# Altium schematic sheet Sheet1.SchDoc(Sheet1)
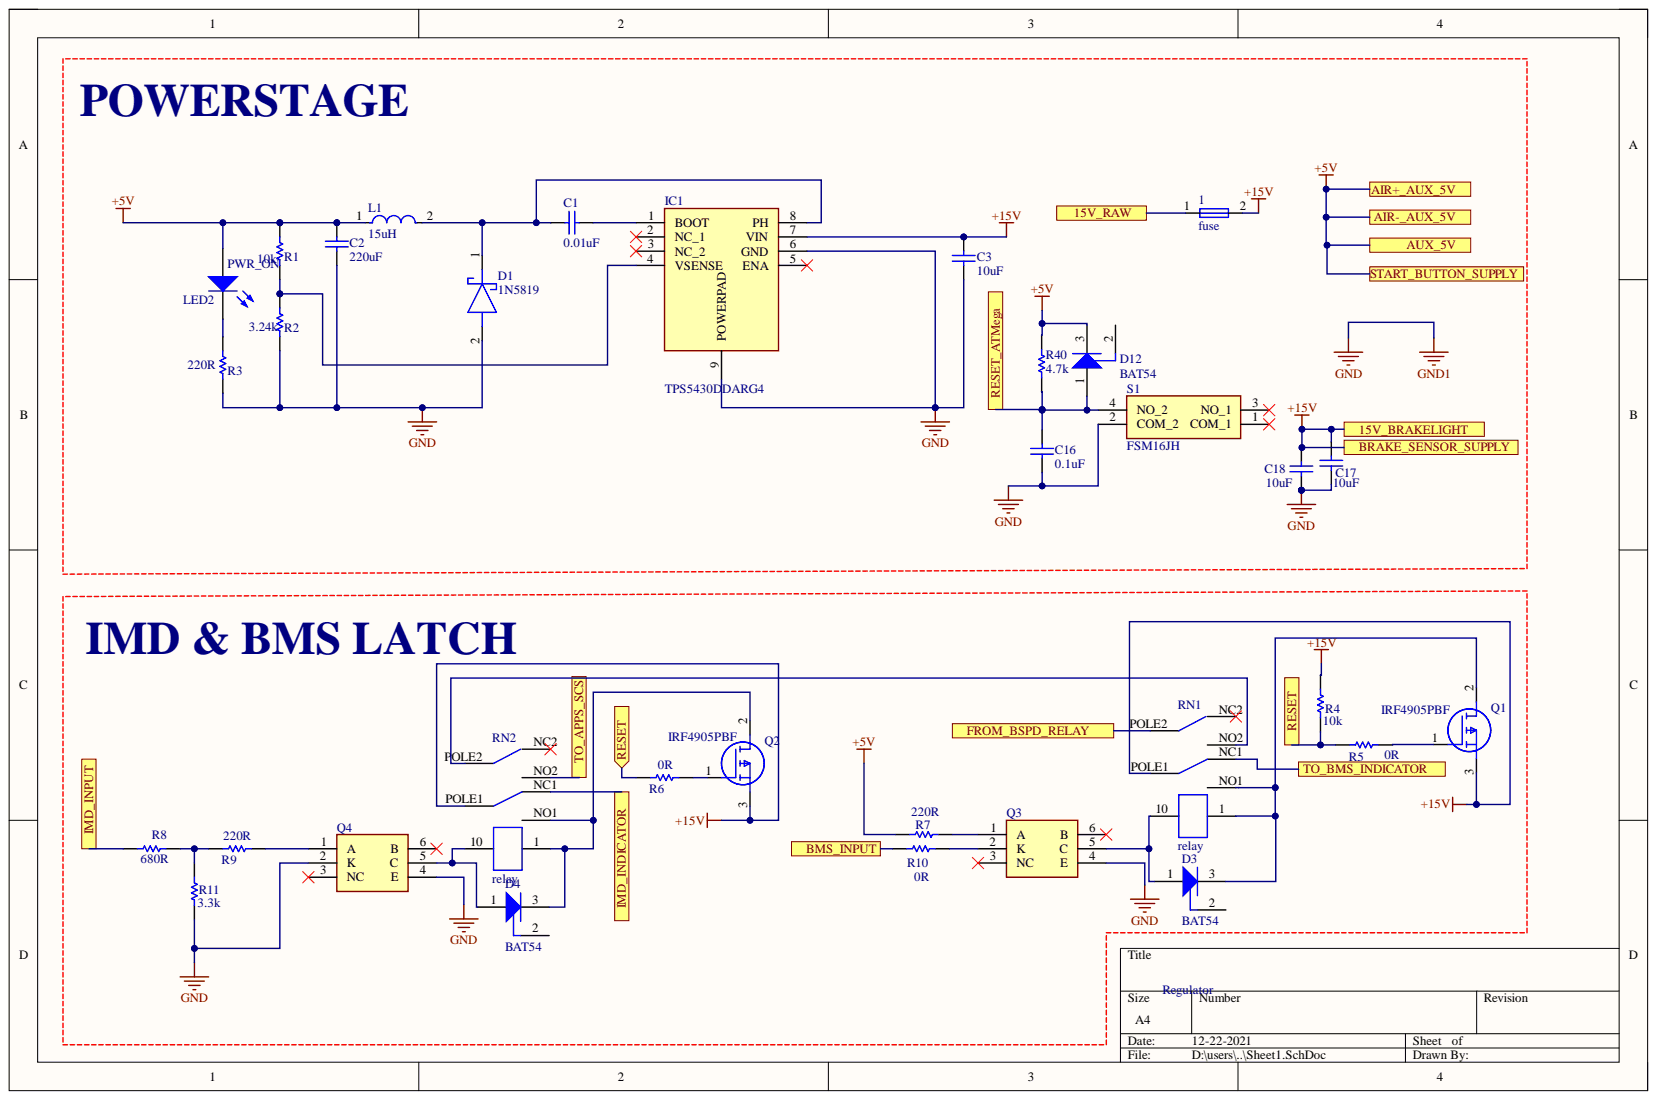
[diagram p0: Sheet1.SchDoc]

## Parts
1  fuse  [fuse]
C1  0.01uF  [KEMT-1206-2_N]
C2  220uF  [KEMT-1206-2_N]
C3  10uF  [KEMT-1206-2_N]
C16  0.1uF  [KEMT-1206-2_N]
C17  10uF  [KEMT-1206-2_N]
C18  10uF  [KEMT-1206-2_N]
D1  1N5819  [DIOAD1796W86L628D292]
D3  BAT54  [INF-SOT23_N]
D4  BAT54  [INF-SOT23_N]
D12  BAT54  [INF-SOT23_N]
IC1  TPS5430DDARG4  [SOIC127P600X175-8N]
L1  15uH  [FP-IR02-MFG]
PWR_ON  LED2  [3.2X1.6X1.1]
Q1  IRF4905PBF  [TO254P469X1042X1967-3P]
Q2  IRF4905PBF  [TO254P469X1042X1967-3P]
Q3  MCT2ESM  [SOT254P916X480-6N]  (value not drawn)
Q4  MCT2ESM  [SOT254P916X480-6N]  (value not drawn)
R1  10k  [RESC3216X60X45NL10T20 - duplicate]
R2  3.24k  [RESC3216X60X45NL10T20 - duplicate]
R3  220R  [RESC3216X60X45NL10T20 - duplicate]
R4  10k  [RESC3216X60X45NL10T20 - duplicate]
R5  0R  [RESC3216X60X45NL10T20 - duplicate]
R6  0R  [RESC3216X60X45NL10T20 - duplicate]
R7  220R  [RESC3216X60X45NL10T20 - duplicate]
R8  680R  [RESC3216X60X45NL10T20 - duplicate]
R9  220R  [RESC3216X60X45NL10T20 - duplicate]
R10  0R  [RESC3216X60X45NL10T20 - duplicate]
R11  3.3k  [RESC3216X60X45NL10T20 - duplicate]
R40  4.7k  [R1206]
RN1  relay  [relaytq]
RN2  relay  [relaytq]
S1  FSM16JH  [FSM16JH]

## Ports
15V_BRAKELIGHT, 15V_RAW, AIR+_AUX_5V, AIR-_AUX_5V, AUX_5V, BMS_INPUT, BRAKE_SENSOR_SUPPLY, FROM_BSPD_RELAY, IMD_INDICATOR, IMD_INPUT, RESET, RESET_ATMega, START_BUTTON_SUPPLY, TO_APPS_SCS, TO_BMS_INDICATOR

## Nets
GND: C2.2 C3.2 C16.1 C17.2 C18.2 D1.2 IC1.6(GND) IC1.9(POWERPAD) Q3.4(E) Q4.2(K) Q4.4(E) R2.1 R3.1 R11.1 S1.2(COM_2)
15V_RAW: 1.1 ; port 15V_RAW
+5V: C2.1 D12.3 L1.1 PWR_ON.1 R1.2 R7.2 R40.1 ; port AUX_5V, port START_BUTTON_SUPPLY
+15V: 1.2 C3.1 C17.1 C18.1 IC1.7(VIN) Q1.3 Q2.3 R4.2 RN2.POLE1 ; port 15V_BRAKELIGHT, port BRAKE_SENSOR_SUPPLY
BMS_INPUT: R10.2 ; port BMS_INPUT
FROM_BSPD_RELAY: RN1.POLE2 ; port FROM_BSPD_RELAY
IMD_INDICATOR: RN2.NC1 ; port IMD_INDICATOR
IMD_INPUT: R8.2 ; port IMD_INPUT
RESET: R4.1 R5.2 R6.2 ; port RESET
RESET_ATMega: C16.2 D12.1 R40.2 S1.4(NO_2) ; port RESET_ATMega
TO_APPS_SCS: RN2.NO2 ; port TO_APPS_SCS
TO_BMS_INDICATOR: RN1.NC1 ; port TO_BMS_INDICATOR
NetC1_1: C1.1 D1.1 IC1.8(PH) L1.2
NetC1_2: C1.2 IC1.1(BOOT)
NetD3_1: D3.1 Q3.5(C) RN1.10
NetD3_3: D3.3 Q1.2 RN1.1 RN1.NO1
NetD4_1: D4.1 Q4.5(C) RN2.10
NetD4_3: D4.3 Q2.2 RN2.1 RN2.NO1
NetIC1_4: IC1.4(VSENSE) R1.1 R2.2
NetPWR_ON_2: PWR_ON.2 R3.2
NetQ1_1: Q1.1 R5.1
NetQ2_1: Q2.1 R6.1
NetQ3_1: Q3.1(A) R7.1
NetQ3_2: Q3.2(K) R10.1
NetQ4_1: Q4.1(A) R9.1
NetR8_1: R8.1 R9.2 R11.2
NetRN1_NO2: RN1.NO2 RN2.POLE2

Not connected: D3 2; D4 2; D12 2; IC1 2(NC_1) 3(NC_2) 5(ENA); Q3 3(NC) 6(B); Q4 3(NC) 6(B); RN1 NC2 POLE1; RN2 NC2; S1 1(COM_1) 3(NO_1)

## Library data of the parts
C1-C3 C16-C18 = CMP-1037-04202-2: CAP, Ceramic, 0.1 uF, +/- 10%, 50 V, -55 to 125 degC, 1206 (3216 Metric), RoHS, Tape and Reel; Manufacturer: Kemet; Capacitance: 0.1µF; Capacitor Case Style: 1206[3216Metric]; Dielectric Characteristic: X7R; Product Range: KEMET-CSeries; SVHC: ToBeAdvised; Voltage Rating: 50V; Capacitance Tolerance: ±10%; Component Kind: Standard; Datasheet URL: https://datasheet.ciiva.com/6125/1725438-6125494.pdf; Datasheet Version: Jul-13; Manufacturer URL: http://www.kemet.com/; Operating Temperature Max: 125degC; Operating Temperature Min: -55degC; Package Description: 2-Leads SMD, Body 3.2 x 1.6 mm; Package Reference: 1206-2; Packaging: Tape&Reel
  C1-C3 C17 C18: Library Name: Schlib1.SchLib
  C16: Library Name: Schlib1_TSAL_LV_3.1.SchLib
D1 = 1N5819: Schottky Diode; Manufacturer_Name: NEUTRAL; Component Kind: Standard; Datasheet Link: http://uk.rs-online.com/web/p/products/1124416; Library Name: SamacSys.SchLib; Manufacturer_Part_Number: 1N5819
D3 D4 D12 = CMP-1182-00010-1: Silicon Schottky Diode, SOT23, Reel, Green; Manufacturer: Infineon; Configuration: Single; CT (max): 10Â pF; Green: TRUE; IF (max): 200Â mA; VF (max): 800Â mV; VR (max): 30Â V; Component Kind: Standard; ComponentLink1Description: Manufacturer URL; ComponentLink1URL: http://www.infineon.com/; ComponentLink2Description: Datasheet; ComponentLink2URL: http://www.infineon.com/dgdl/bat54series.pdf?folderId=db3a30431400ef68011425f1ca2505e3&fileId=db3a304314dca3890115190b74210ea6; ComponentLink3Description: Package Specification; ComponentLink3URL: http://www.infineon.com/dgdl/SOT23-Package_Overview.pdf?folderId=db3a30431936bc4b0119532290053c66&fileId=db3a30431936bc4b0119533468123c85; Mounting Technology: Surface Mount; PackageDescription: 3-Pin Small Outline Transistor Package, 1.3 x 2.9 mm Body, 0.95 mm Pitch; PackageReference: SOT23; PackageVersion: Apr-08; Packing: Reel; PartNumber: BAT54
  D3 D4: Library Name: Schlib1.SchLib
  D12: Library Name: Schlib1_TSAL_LV_3.1.SchLib
IC1 = TPS5430DDARG4: Integrated Circuit; Manufacturer_Name: Texas Instruments; Component Kind: Standard; Datasheet Link: http://www.ti.com/general/docs/suppproductinfo.tsp?distId=10&gotoUrl=http%3A%2F%2Fwww.ti.com%2Flit%2Fgpn%2Ftps5431; Height: 1.7mm; Library Name: Schlib1.SchLib; Manufacturer_Part_Number: TPS5430DDARG4; Mouser Part Number: 595-TPS5430DDARG4; Mouser Price/Stock: https://www.mouser.co.uk/ProductDetail/Texas-Instruments/TPS5430DDARG4?qs=zwk82MlA57YG4K%2FfUMTveg%3D%3D
L1 = CMP-03630-000001-1: IR-2 10 10% B08; Manufacturer: Vishay Dale; Manufacturer Part Number: IR02BH100K; Obsolete Part: true; Core Material: Iron; Inductance: 10uH; Q Factor: 55; Saturation Current: 130mA; Self Resonant Frequency: 50MHz; Series Resistance: 3.7Ω; Test Frequency: 7.9MHz; Value: 10uH; Automotive: No; Category: Ind; Component Kind: Standard; ComponentLink2Description: Datasheet; ComponentLink2URL: https://datasheet.ciiva.com/8536/ir-8536517.pdf; Device Class L1: Passive Components; Device Class L2: Inductors; Device Class L3: Fixed Inductors; Digikey Description: IR-2 10 10% B08; Height: 3.05mm; Ipc Land Pattern Name: CAPAD1147W70L838D305; Lead Free: Yes; Library Name: Schlib1.SchLib; Mouser Description: Fixed Inductors 10uH 10%; Octopart: https://octopart.com/ir02bh100k-vishay+dale-39675774; Package: IR02; RoHS: TRUE; Temperature: -55°C to +105°C; Temperature Range High: +105°C; Temperature Range Low: -55°C; Tolerance: 10%
PWR_ON = LED2: Typical RED, GREEN, YELLOW, AMBER GaAs LED; Component Kind: Standard; LatestRevisionDate: 17-Jul-2002; LatestRevisionNote: Re-released for DXP Platform.; Library Name: Miscellaneous Devices.IntLib; PackageDescription: SM LED; 2 Flat Leads; PackageReference: 3.2X1.6X1.1; PackageVersion: Feb-2002; Published: 8-Jun-2000; Simulation: LED2; Publisher: Altium Limited
Q1 Q2 = IRF4905PBF: MOSFET (P-Channel); Manufacturer_Name: Infineon; Arrow Part Number: IRF4905PBF; Arrow Price/Stock: https://www.arrow.com/en/products/irf4905pbf/infineon-technologies-ag; Component Kind: Standard; Datasheet Link: http://docs-emea.rs-online.com/webdocs/0791/0900766b807910f2.pdf; Height: 4.69mm; Library Name: SamacSys.SchLib; Manufacturer_Part_Number: IRF4905PBF; Mouser Part Number: 942-IRF4905PBF; Mouser Price/Stock: https://www.mouser.co.uk/ProductDetail/Infineon-IR/IRF4905PBF/?qs=9%252BKlkBgLFf39l0HsWdxvdw%3D%3D
Q3 Q4 = MCT2ESM: Phototransistor; Manufacturer_Name: ON Semiconductor; Arrow Part Number: MCT2ESM; Arrow Price/Stock: https://www.arrow.com/en/products/mct2esm/on-semiconductor; Component Kind: Standard; Datasheet Link: https://www.onsemi.com/pub/Collateral/MCT2EM-D.PDF; Height: 4.8mm; Library Name: SamacSys.SchLib; Manufacturer_Part_Number: MCT2ESM; Mouser Part Number: 512-MCT2ESM; Mouser Price/Stock: https://www.mouser.co.uk/ProductDetail/ON-Semiconductor-Fairchild/MCT2ESM/?qs=nW0pe8qlIZjLnc6UyUzYTw%3D%3D
R1-R11 = CMP-2003-02213-1: Manufacturer: Vishay Dale; Part Number: CRCW120647K0FKEA; Component Kind: Standard; Library Name: Schlib1.SchLib
R40 = RES: Manufacturer: Vishay Dale; Part Number: CRCW120647K0FKEA; Component Kind: Standard; Library Name: Schlib1.SchLib
S1 = FSM16JH: Switch; Manufacturer_Name: TE Connectivity; Arrow Part Number: FSM16JH; Arrow Price/Stock: https://www.arrow.com/en/products/fsm16jh/te-connectivity; Component Kind: Standard; Datasheet Link: https://www.te.com/commerce/DocumentDelivery/DDEController?Action=showdoc&DocId=Customer+Drawing%7F1825910%7FC10%7Fpdf%7FEnglish%7FENG_CD_1825910_C10.pdf%7F3-1825910-1; Height: 17.3mm; Library Name: SamacSys.SchLib; Manufacturer_Part_Number: FSM16JH; Mouser Part Number: 506-FSM16JH; Mouser Price/Stock: https://www.mouser.co.uk/ProductDetail/TE-Connectivity-PB/FSM16JH/?qs=eA0sQYb2Mifk48vugSh4aw%3D%3D
1 = fuse: Component Kind: Standard; Library Name: Schlib1.SchLib
RN1 RN2 = relay: Component Kind: Standard; Library Name: Schlib1.SchLib
